# Altium schematic sheet TopLevel.SchDoc(TopLevel)
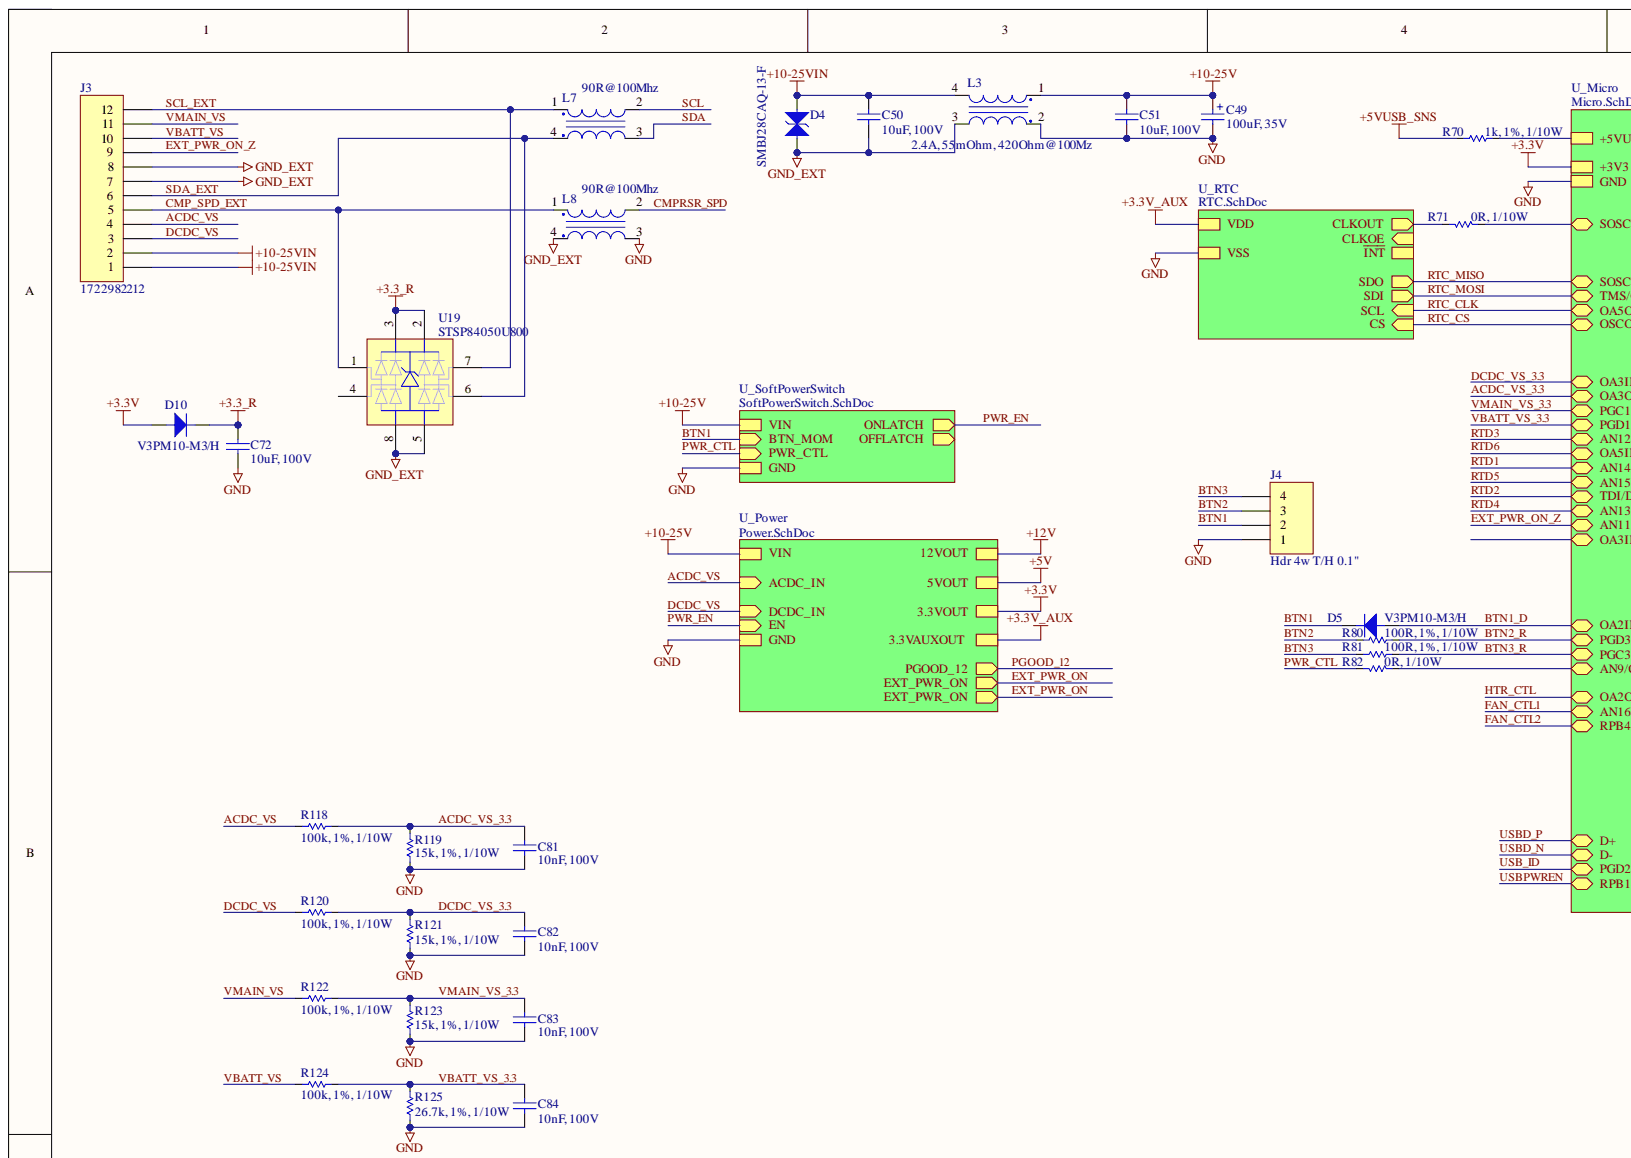
[diagram p0: TopLevel.SchDoc, top left]
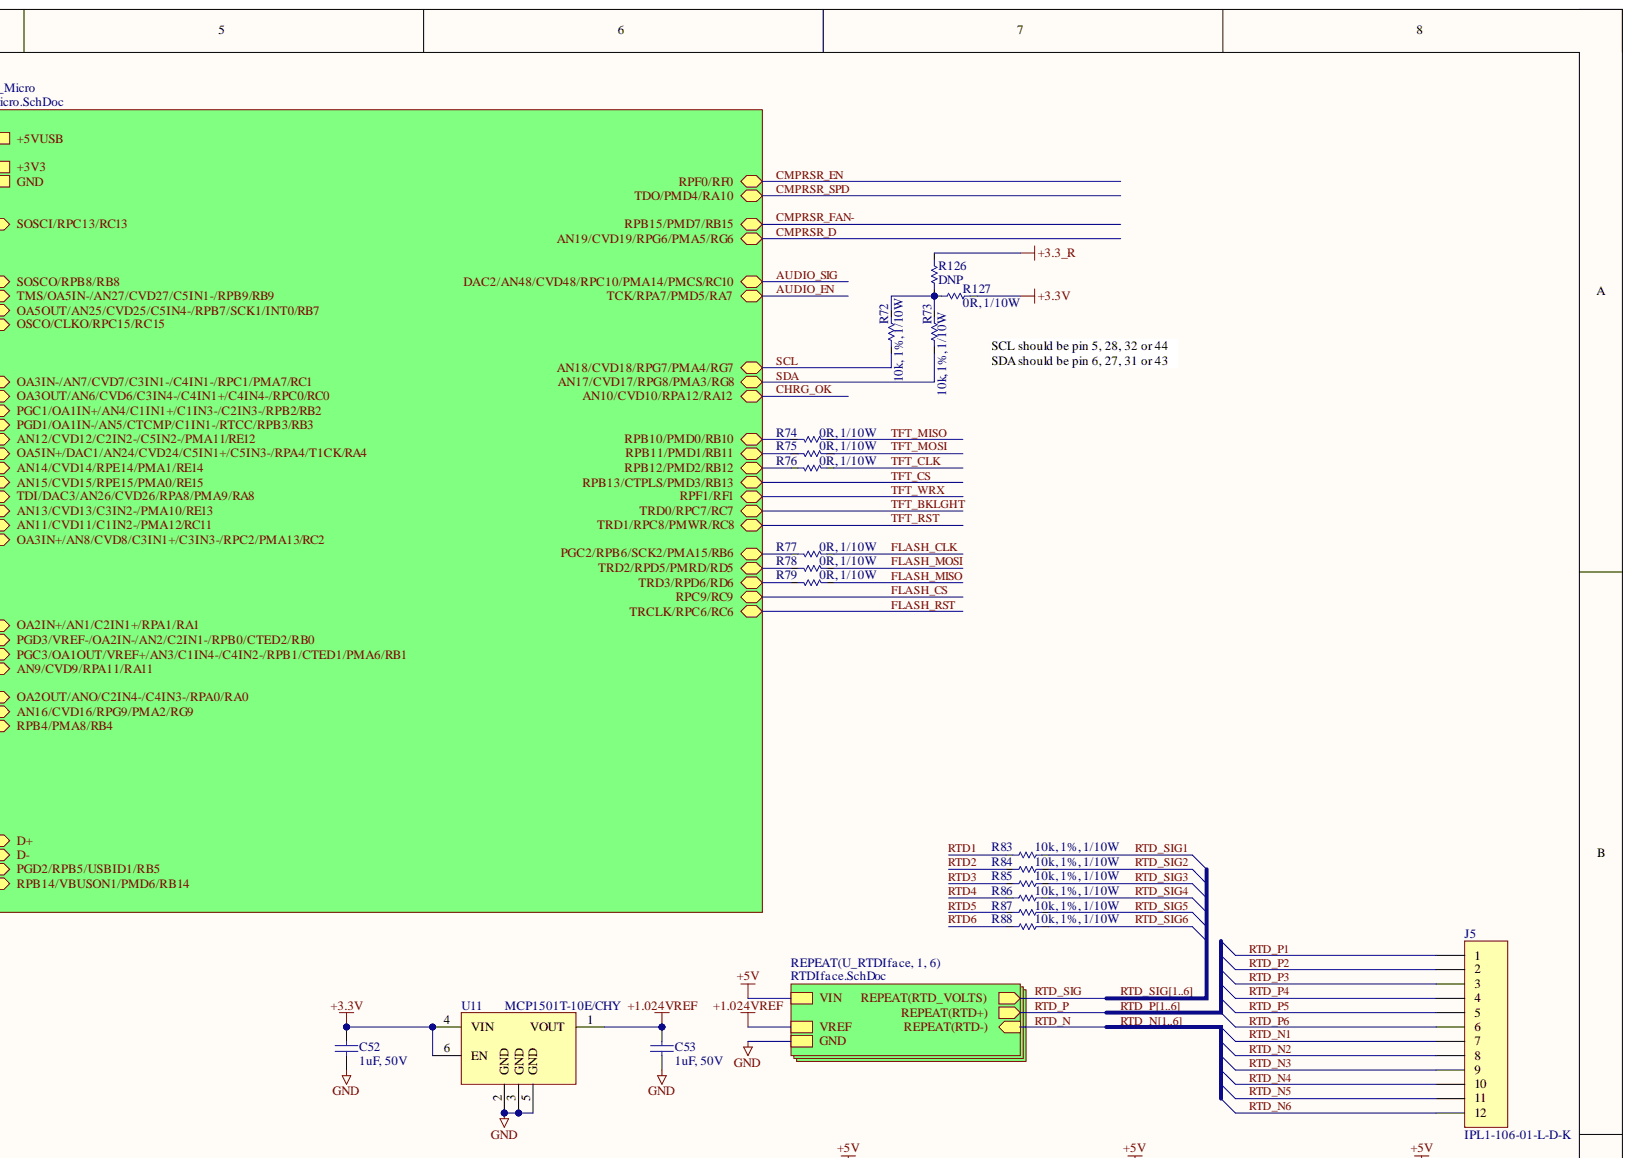
[diagram p1: TopLevel.SchDoc, top right]
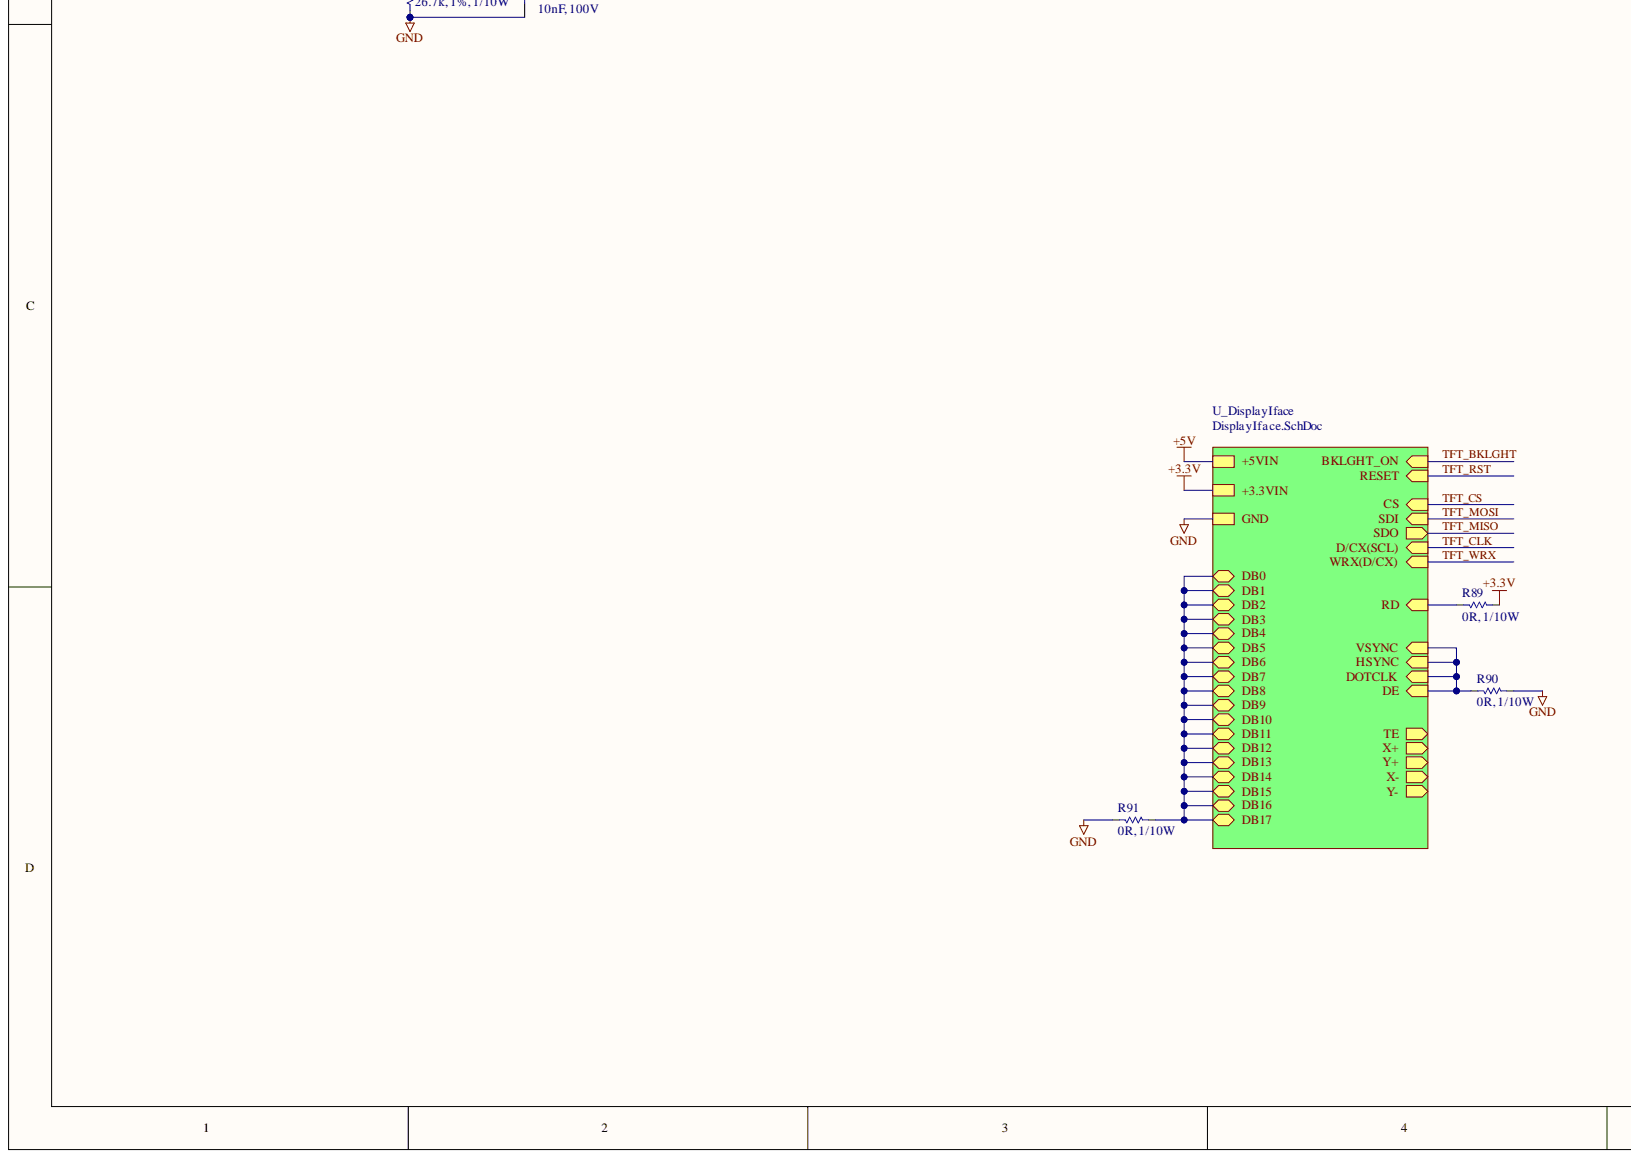
[diagram p2: TopLevel.SchDoc, bottom left]
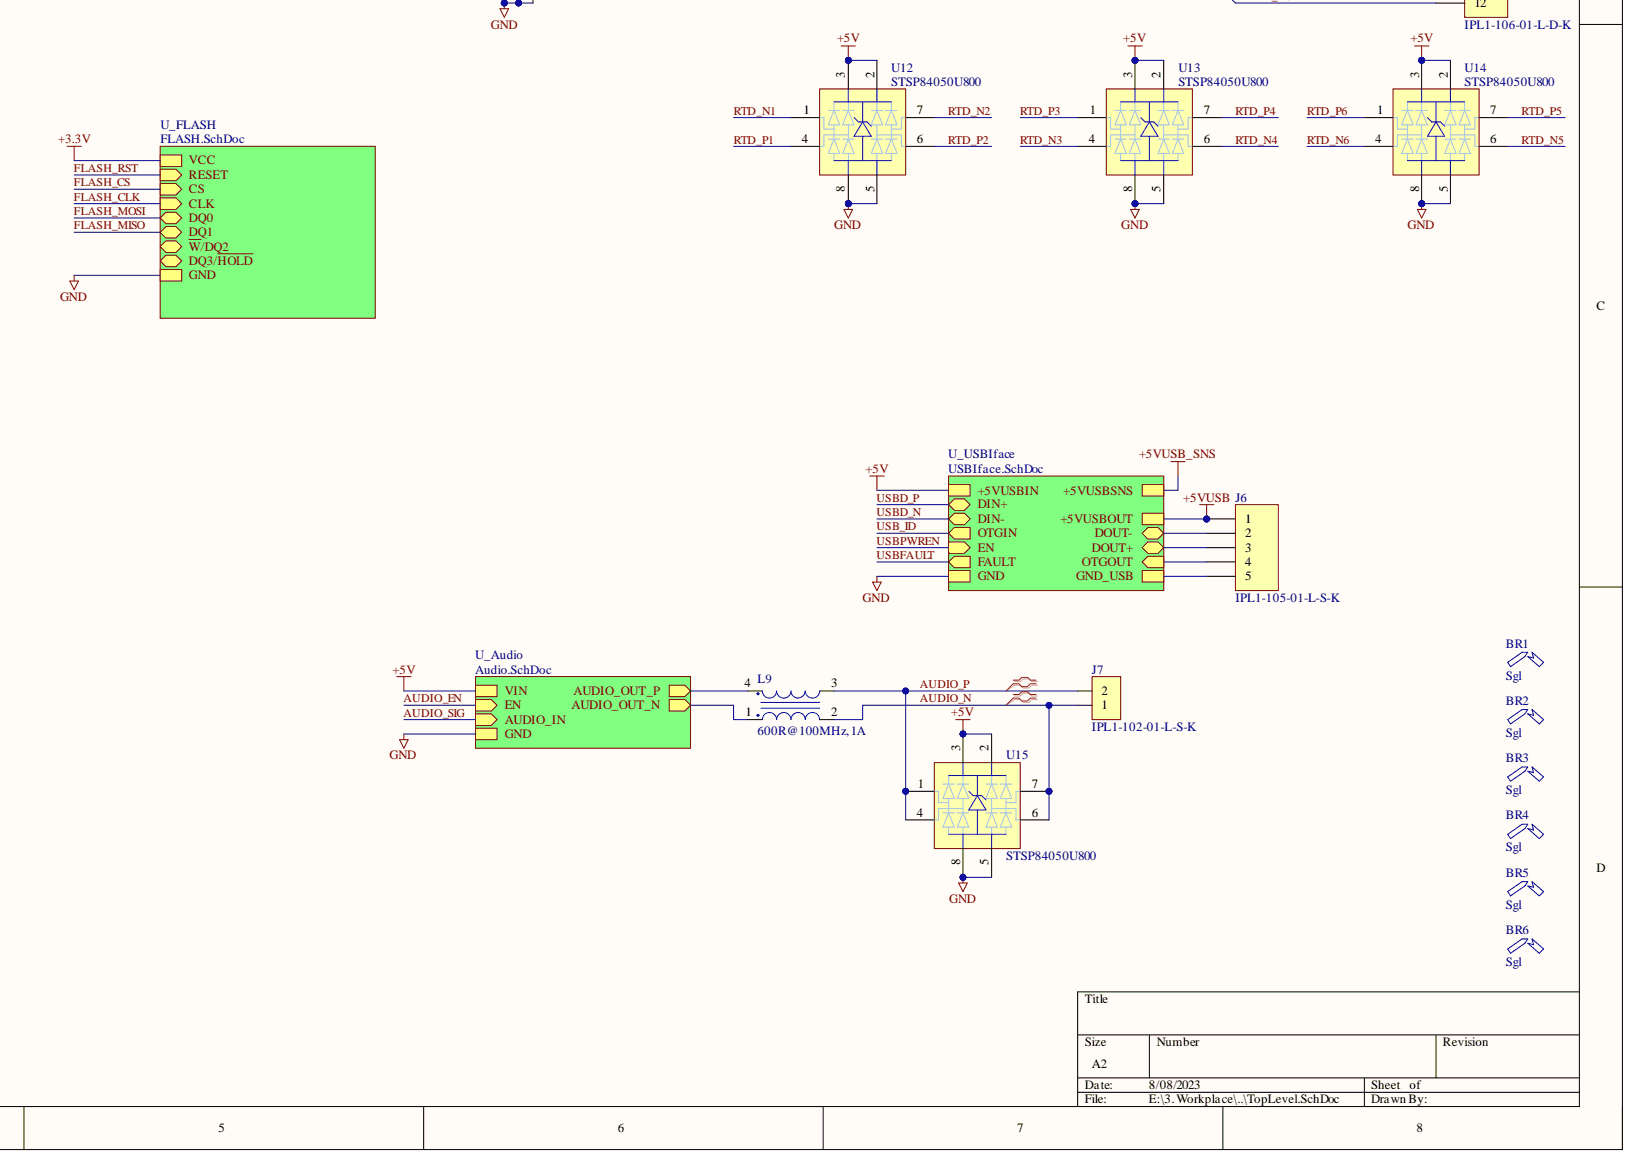
[diagram p3: TopLevel.SchDoc, bottom right]

## Parts
BR1  Sgl  [BREAKOUT_3]  (no BOM)
BR2  Sgl  [BREAKOUT_3]  (no BOM)
BR3  Sgl  [BREAKOUT_3]  (no BOM)
BR4  Sgl  [BREAKOUT_3]  (no BOM)
BR5  Sgl  [BREAKOUT_3]  (no BOM)
BR6  Sgl  [BREAKOUT_3]  (no BOM)
C49  100uF, 35V  [CAPAE7866X80L]
C50  10uF, 100V  [1210]
C51  10uF, 100V  [1210]
C52  1uF, 50V  [0805]
C53  1uF, 50V  [0805]
C72  10uF, 100V  [1210]
C81  10nF, 100V  [0603]
C82  10nF, 100V  [0603]
C83  10nF, 100V  [0603]
C84  10nF, 100V  [0603]
D4  SMBJ28CAQ-13-F  [SMB]
D5  V3PM10-M3/H  [SMP]
D10  V3PM10-M3/H  [SMP]
J3  1722982212  [CONN_MOLEX_1722982212]
J4  Hdr 4w T/H 0.1"  [CONN_HDR_FRICTION_LOCK_2.54MM_4]
J5  IPL1-106-01-L-D-K  [CONN_SAMTEC_IPL1-106-XX-X-D]
J6  IPL1-105-01-L-S-K  [CONN_SAMTEC_IPL1-105-XX-X-S]
J7  IPL1-102-01-L-S-K  [CONN_SAMTEC_IPL1-102-XX-X-S]
L3  2.4A, 55mOhm, 420Ohm@100Mz  [CHOKE_SMD_4.7X4.5]
L7  90R@100Mhz  [BOURNS_SRF2012]
L8  90R@100Mhz  [BOURNS_SRF2012]
L9  600R@100MHz, 1A  [DFN260P320X200-4L]
R70  1k, 1%, 1/10W  [0603]
R71  0R, 1/10W  [0603]
R72  10k, 1%, 1/10W  [0603]
R73  10k, 1%, 1/10W  [0603]
R74  0R, 1/10W  [0603]
R75  0R, 1/10W  [0603]
R76  0R, 1/10W  [0603]
R77  0R, 1/10W  [0603]
R78  0R, 1/10W  [0603]
R79  0R, 1/10W  [0603]
R80  100R, 1%, 1/10W  [0603]
R81  100R, 1%, 1/10W  [0603]
R82  0R, 1/10W  [0603]
R83  10k, 1%, 1/10W  [0603]
R84  10k, 1%, 1/10W  [0603]
R85  10k, 1%, 1/10W  [0603]
R86  10k, 1%, 1/10W  [0603]
R87  10k, 1%, 1/10W  [0603]
R88  10k, 1%, 1/10W  [0603]
R89  0R, 1/10W  [0603]
R90  0R, 1/10W  [0603]
R91  0R, 1/10W  [0603]
R118  100k, 1%, 1/10W  [0603]
R119  15k, 1%, 1/10W  [0603]
R120  100k, 1%, 1/10W  [0603]
R121  15k, 1%, 1/10W  [0603]
R122  100k, 1%, 1/10W  [0603]
R123  15k, 1%, 1/10W  [0603]
R124  100k, 1%, 1/10W  [0603]
R125  26.7k, 1%, 1/10W  [0603]
R126  DNP  [0603]
R127  0R, 1/10W  [0603]
U11  MCP1501T-10E/CHY  [SOT23-6]
U12  STSP84050U800  [SOIC127P600X163-8N]
U13  STSP84050U800  [SOIC127P600X163-8N]
U14  STSP84050U800  [SOIC127P600X163-8N]
U15  STSP84050U800  [SOIC127P600X163-8N]
U19  STSP84050U800  [SOIC127P600X163-8N]

## Nets
+1.024VREF: C53.2 U11.1(VOUT)
+3.3_R: C72.2 D10.2 R126.2 U19.2 U19.3
+3.3V: C52.2 D10.1 R89.1 R127.2 U11.4(VIN) U11.6(EN)
+5V: U12.2 U12.3 U13.2 U13.3 U14.2 U14.3 U15.2 U15.3
+5VUSB: J6.1
+5VUSB_SNS: R70.2
+10-25V: C49.1 C51.2 L3.1
+10-25VIN: C50.2 D4.2 J3.1 J3.2 L3.4
GND: C49.2 C51.1 C52.1 C53.1 C72.1 C81.1 C82.1 C83.1 C84.1 J4.1 L3.2 L8.3 R90.1 R91.2 R119.1 R121.1 R123.1 R125.1 U11.2(GND) U11.3(GND) U11.5(GND) U12.5 U12.8 U13.5 U13.8 U14.5 U14.8 U15.5 U15.8
GND_EXT: C50.1 D4.1 J3.7 J3.8 L3.3 L8.4 U19.5 U19.8
~{BTN1}: D5.2 J4.2
~{BTN1_D}: D5.1
~{BTN2}: J4.3 R80.1
~{BTN2_R}: R80.2
~{BTN3}: J4.4 R81.1
~{BTN3_R}: R81.2
ACDC_VS: J3.4 R118.1
ACDC_VS_3.3: C81.2 R118.2 R119.2
AUDIO_N: J7.1 L9.2 U15.6 U15.7
AUDIO_P: J7.2 L9.3 U15.1 U15.4
CMP_SPD_EXT: J3.5 L8.1 U19.1
CMPRSR_SPD: L8.2
DCDC_VS: J3.3 R120.1
DCDC_VS_3.3: C82.2 R120.2 R121.2
EXT_PWR_ON_Z: J3.9
FLASH_CLK: R77.2
FLASH_MISO: R79.2
FLASH_MOSI: R78.2
PWR_CTL: R82.1
RTD1: R83.2
RTD2: R84.2
RTD3: R85.2
RTD4: R86.2
RTD5: R87.2
RTD6: R88.2
RTD_N1: J5.7 U12.1  [also labelled RTD_N]
RTD_N2: J5.8 U12.7  [also labelled RTD_N]
RTD_N3: J5.9 U13.4  [also labelled RTD_N]
RTD_N4: J5.10 U13.6  [also labelled RTD_N]
RTD_N5: J5.11 U14.6  [also labelled RTD_N]
RTD_N6: J5.12 U14.4  [also labelled RTD_N]
RTD_P1: J5.1 U12.4  [also labelled RTD_P]
RTD_P2: J5.2 U12.6  [also labelled RTD_P]
RTD_P3: J5.3 U13.1  [also labelled RTD_P]
RTD_P4: J5.4 U13.7  [also labelled RTD_P]
RTD_P5: J5.5 U14.7  [also labelled RTD_P]
RTD_P6: J5.6 U14.1  [also labelled RTD_P]
RTD_SIG1: R83.1  [also labelled RTD_SIG]
RTD_SIG2: R84.1  [also labelled RTD_SIG]
RTD_SIG3: R85.1  [also labelled RTD_SIG]
RTD_SIG4: R86.1  [also labelled RTD_SIG]
RTD_SIG5: R87.1  [also labelled RTD_SIG]
RTD_SIG6: R88.1  [also labelled RTD_SIG]
SCL: L7.2 R72.2
SCL_EXT: J3.12 L7.1 U19.7
SDA: L7.3 R73.2
SDA_EXT: J3.6 L7.4 U19.6
TFT_CLK: R76.2
TFT_MISO: R74.2
TFT_MOSI: R75.2
VBATT_VS: J3.10 R124.1
VBATT_VS_3.3: C84.2 R124.2 R125.2
VMAIN_VS: J3.11 R122.1
VMAIN_VS_3.3: C83.2 R122.2 R123.2
NetJ6_2: J6.2
NetJ6_3: J6.3
NetJ6_4: J6.4
NetJ6_5: J6.5
NetL9_1: L9.1
NetL9_4: L9.4
NetR70_1: R70.1
NetR71_1: R71.1
NetR71_2: R71.2
NetR72_1: R72.1 R73.1 R126.1 R127.1
NetR74_1: R74.1
NetR75_1: R75.1
NetR76_1: R76.1
NetR77_1: R77.1
NetR78_1: R78.1
NetR79_1: R79.1
NetR82_2: R82.2
NetR89_2: R89.2
NetR90_2: R90.2
NetR91_1: R91.1
NetU19_4: U19.4
+3.3V_AUX:
+12V:
~{EXT_PWR_ON}:
~{FLASH_CS}:
~{FLASH_RST}:
~{OFFLATCH}:
~{PGOOD_12}:
~{PWR_EN}:
~{RTC_CS}:
~{TFT_CS}:
~{TFT_RST}:
~{USBFAULT}:
~{USBPWREN}:
AUDIO_EN:
AUDIO_SIG:
CHRG_OK:
CLKOE:
CMPRSR_D:
CMPRSR_EN:
CMPRSR_FAN-:
DQ3/~{HOLD}:
EXT_PWR_ON:
FAN_CTL1:
FAN_CTL2:
HTR_CTL:
~{INT}:
OA3IN+/AN8/CVD8/C3IN1+/C3IN3-/RPC2/PMA13/RC2:
RTC_CLK:
RTC_MISO:
RTC_MOSI:
TE:
TFT_BKLGHT:
TFT_WRX:
USB_ID:
USBD_N:
USBD_P:
~{W}/DQ2:
X+:
X-:
Y+:
Y-:

## Library data of the parts
BR1-BR6 = NS00209: MOUSEBITE, SGL, 3HOLE; Alternatives: Generic; Database Table Name: AllParts; Library Name: FullPartsDB.SVNDbLib; Part Number: NS00209; Type: Mechanical; Value: Sgl
C49 = NS00911: CAP ALUM 100UF 20% 35V SMD; Alternatives: Generic; Database Table Name: AllParts; Type: C; Component Kind: Standard; Library Name: FullPartsDB.SVNDbLib; Part Number: NS00911; Supplier 1: Digi-Key; Supplier Part Number 1: PCE5051CT-ND; Value: 100uF, 35V
C50 C51 C72 = NS00779: MLCC 10UF 100V X7S 1210; Alternatives: Generic; Database Table Name: AllParts; Type: C; Component Kind: Standard; Library Name: FullPartsDB.SVNDbLib; Part Number: NS00779; Supplier 1: Digi-Key; Supplier Part Number 1: 1276-CL32Y106KCVZNWECT-ND; Value: 10uF, 100V
C52 C53 = NS00871: CAP CER 1UF 50V X7R 0805; Alternatives: Generic; Database Table Name: AllParts; Type: C; Component Kind: Standard; Library Name: FullPartsDB.SVNDbLib; Part Number: NS00871; Supplier 1: Digi-Key; Supplier Part Number 1: 1276-6470-1-ND; Value: 1uF, 50V
C81-C84 = NS00848: CAP CER 10000PF 100V C0G 0603; Alternatives: Generic; Database Table Name: AllParts; Type: C; Component Kind: Standard; Library Name: FullPartsDB.SVNDbLib; Part Number: NS00848; Supplier 1: Digi-Key; Supplier Part Number 1: 445-172665-1-ND; Value: 10nF, 100V
D4 = NS00890: TVS DIODE 28VWM 45.4VC SMB; Database Table Name: AllParts; Component Kind: Standard; ComponentLink1Description: Datasheet; Library Name: FullPartsDB.SVNDbLib; Part Number: NS00890; Supplier 1: Digi-Key; Supplier Part Number 1: SMBJ28CAQ-13-FDICT-ND; Type: Diode; Value: SMBJ28CAQ-13-F; ComponentLink1URL: H:\Doc\Diodes\SMBJ6.5CAQ-SMBJ100CAQ.pdf
D5 D10 = NS00857: SCHOTTKY RECTIFIER 3A 100V SMP; Database Table Name: AllParts; Type: Diode; Component Kind: Standard; ComponentLink1Description: Datasheet; Library Name: FullPartsDB.SVNDbLib; Part Number: NS00857; Supplier 1: Digi-Key; Supplier Part Number 1: 112-V3PM10-M3/HCT-ND; Value: V3PM10-M3/H; ComponentLink1URL: H:\Doc\Vishay\v3pm10.pdf
J3 = NS00894: CONN HEADER VERT 12POS 3.5MM; Database Table Name: AllParts; Component Kind: Standard; ComponentLink1Description: Datasheet; ComponentLink2Description: Application Specification; ComponentLink3Description: Drawing; Library Name: FullPartsDB.SVNDbLib; Part Number: NS00894; Supplier 1: Digi-Key; Supplier Part Number 1: WM11767-ND; Type: Connector; Value: 1722982212; ComponentLink1URL: H:\Doc\Molex\1722982212_PCB_HEADERS.pdf; ComponentLink2URL: H:\Doc\Molex\AS-172323-0001-001.pdf; ComponentLink3URL: H:\Doc\Molex\1722982212_sd.pdf
J4 = NS00337: 4 Positions Header, Unshrouded Connector 0.100" (2.54mm) Through Hole Gold; Alternatives: Generic; Database Table Name: AllParts; Component Kind: Standard; ComponentLink1Description: Datasheet; ComponentLink2Description: Drawing; Library Name: FullPartsDB.SVNDbLib; Part Number: NS00337; Supplier 1: Digi-Key; Supplier Part Number 1: WM2702-ND; Type: Connector; Value: Hdr 4w T/H 0.1"; ComponentLink1URL: H:\Doc\Molex\PS-10-07.pdf; ComponentLink2URL: H:\Doc\Molex\022112022_sd.pdf
J5 = NS00892: CONN HEADER VERT 12POS 2.54MM; Database Table Name: AllParts; Component Kind: Standard; ComponentLink1Description: Datasheet; ComponentLink2Description: Dimensions; Library Name: FullPartsDB.SVNDbLib; Part Number: NS00892; Supplier 1: Digi-Key; Supplier Part Number 1: SAM8941-ND; Type: Connector; Value: IPL1-106-01-L-D-K; ComponentLink1URL: H:\Doc\Samtec\100_mini_mate.pdf; ComponentLink2URL: H:\Doc\Samtec\ipl1.pdf
J6 = NS00898: CONN HEADER VERT 5POS 2.54MM; Database Table Name: AllParts; Component Kind: Standard; ComponentLink1Description: Datasheet; ComponentLink2Description: Dimensions; Library Name: FullPartsDB.SVNDbLib; Part Number: NS00898; Supplier 1: Digi-Key; Supplier Part Number 1: SAM10577-ND; Type: Connector; Value: IPL1-105-01-L-S-K; ComponentLink1URL: H:\Doc\Samtec\100_mini_mate.pdf; ComponentLink2URL: H:\Doc\Samtec\ipl1.pdf
J7 = NS00896: CONN HEADER VERT 2POS 2.54MM; Database Table Name: AllParts; Component Kind: Standard; ComponentLink1Description: Datasheet; ComponentLink2Description: Dimensions; Library Name: FullPartsDB.SVNDbLib; Part Number: NS00896; Supplier 1: Digi-Key; Supplier Part Number 1: SAM8824-ND; Type: Connector; Value: IPL1-102-01-L-S-K; ComponentLink1URL: H:\Doc\Samtec\100_mini_mate.pdf; ComponentLink2URL: H:\Doc\Samtec\ipl1.pdf
L3 = NS00257: CHOKE COMMON MODE 420 OHM SMD; Database Table Name: AllParts; Type: Inductor; Component Kind: Standard; ComponentLink1Description: Datasheet; Library Name: FullPartsDB.SVNDbLib; Part Number: NS00257; Supplier 1: Digi-Key; Supplier Part Number 1: 445-8644-1-ND; Value: 2.4A, 55mOhm, 420Ohm@100Mz; ComponentLink1URL: H:\Doc\TDK\cmf_commercial_power_acm4520_en.pdf
L7 L8 = NS00042: INDUCTOR COMMON MODE 90 OHM 25%; Database Table Name: AllParts; Component Kind: Standard; ComponentLink1Description: Datasheet; Library Name: FullPartsDB.SVNDbLib; Part Number: NS00042; Supplier 1: Digi-Key; Supplier Part Number 1: SRF2012-900YATR-ND; Type: Inductor; Value: 90R@100Mhz; ComponentLink1URL: H:\Doc\Bourns\SRF2012A.pdf
L9 = NS00953: CMC 1A 2LN 600 OHM SMD; Database Table Name: AllParts; Type: Inductor; Component Kind: Standard; ComponentLink1Description: Datasheet; Library Name: FullPartsDB.SVNDbLib; Part Number: NS00953; Supplier 1: Digi-Key; Supplier Part Number 1: 553-PE-1210CCMC601STSCT-ND; Value: 600R@100MHz, 1A; ComponentLink1URL: H:\Doc\pulse\datasheet_w719_1608373692.pdf
R70 = NS00882: RES 1K OHM 1% 1/10W 0603; Alternatives: Generic; Database Table Name: AllParts; Component Kind: Standard; Library Name: FullPartsDB.SVNDbLib; Part Number: NS00882; Supplier 1: Digi-Key; Supplier Part Number 1: RMCF0603FT1K00CT-ND; Type: R; Value: 1k, 1%, 1/10W
R71 R74-R79 R82 R89-R91 R127 = NS00876: RES 0 OHM JUMPER 1/10W 0603; Alternatives: Generic; Database Table Name: AllParts; Component Kind: Standard; Library Name: FullPartsDB.SVNDbLib; Part Number: NS00876; Supplier 1: Digi-Key; Supplier Part Number 1: RMCF0603ZT0R00CT-ND; Type: R; Value: 0R, 1/10W
R72 R73 R83-R88 = NS00820: RES 10K OHM 1% 1/10W 0603; Alternatives: Generic; Database Table Name: AllParts; Component Kind: Standard; Library Name: FullPartsDB.SVNDbLib; Part Number: NS00820; Supplier 1: Digi-Key; Supplier Part Number 1: RMCF0603FT10K0CT-ND; Type: R; Value: 10k, 1%, 1/10W
R80 R81 = NS00870: RES 100 OHM 1% 1/10W 0603; Alternatives: Generic; Database Table Name: AllParts; Component Kind: Standard; Library Name: FullPartsDB.SVNDbLib; Part Number: NS00870; Supplier 1: Digi-Key; Supplier Part Number 1: RMCF0603FT100RCT-ND; Type: R; Value: 100R, 1%, 1/10W
R118 R120 R122 R124 = NS00835: RES 100K OHM 1% 1/10W 0603; Alternatives: Generic; Database Table Name: AllParts; Component Kind: Standard; Library Name: FullPartsDB.SVNDbLib; Part Number: NS00835; Supplier 1: Digi-Key; Supplier Part Number 1: RMCF0603FT100KCT-ND; Type: R; Value: 100k, 1%, 1/10W
R119 R121 R123 = NS00834: RES 15K OHM 1% 1/10W 0603; Alternatives: Generic; Database Table Name: AllParts; Component Kind: Standard; Library Name: FullPartsDB.SVNDbLib; Part Number: NS00834; Supplier 1: Digi-Key; Supplier Part Number 1: 1292-WR06X1502FTLCT-ND; Type: R; Value: 15k, 1%, 1/10W
R125 = NS00823: RES 26.7K OHM 1% 1/10W 0603; Alternatives: Generic; Database Table Name: AllParts; Component Kind: Standard; Library Name: FullPartsDB.SVNDbLib; Part Number: NS00823; Supplier 1: Digi-Key; Supplier Part Number 1: RMCF0603FT26K7; Type: R; Value: 26.7k, 1%, 1/10W
R126 = NS00876: RES 0 OHM JUMPER 1/10W 0603; Alternatives: Generic; Database Table Name: AllParts; Value: 0R, 1/10W; Component Kind: Standard; Library Name: FullPartsDB.SVNDbLib; Part Number: NS00876; Supplier 1: Digi-Key; Supplier Part Number 1: RMCF0603ZT0R00CT-ND; Type: R
U11 = NS00919: IC VREF SERIES 0.1% SOT23-6; Database Table Name: AllParts; Component Kind: Standard; ComponentLink1Description: Datasheet; Library Name: FullPartsDB.SVNDbLib; Part Number: NS00919; Supplier 1: Digi-Key; Supplier Part Number 1: MCP1501T-10E/CHYCT-ND; Type: IC; Value: MCP1501T-10E/CHY; ComponentLink1URL: H:\Doc\Microchip\20005474E-1.pdf
U12-U15 U19 = NS00913: TVS DIODE 5VWM 20VC 8-SOP; Database Table Name: AllParts; Component Kind: Standard; ComponentLink1Description: Datasheet; Library Name: FullPartsDB.SVNDbLib; Part Number: NS00913; Supplier 1: Digi-Key; Supplier Part Number 1: 283-STSP84050U800CT-ND; Type: IC; Value: STSP84050U800; ComponentLink1URL: H:\Doc\Eaton\eaton-stsp84xxxxxx-tvs-diode-array-esd-suppressor-data-sheet.pdf
